# Altium schematic sheet RPi_DAQ_Hat_Connections.SchDoc(RPi_DAQ_Hat_Connections)
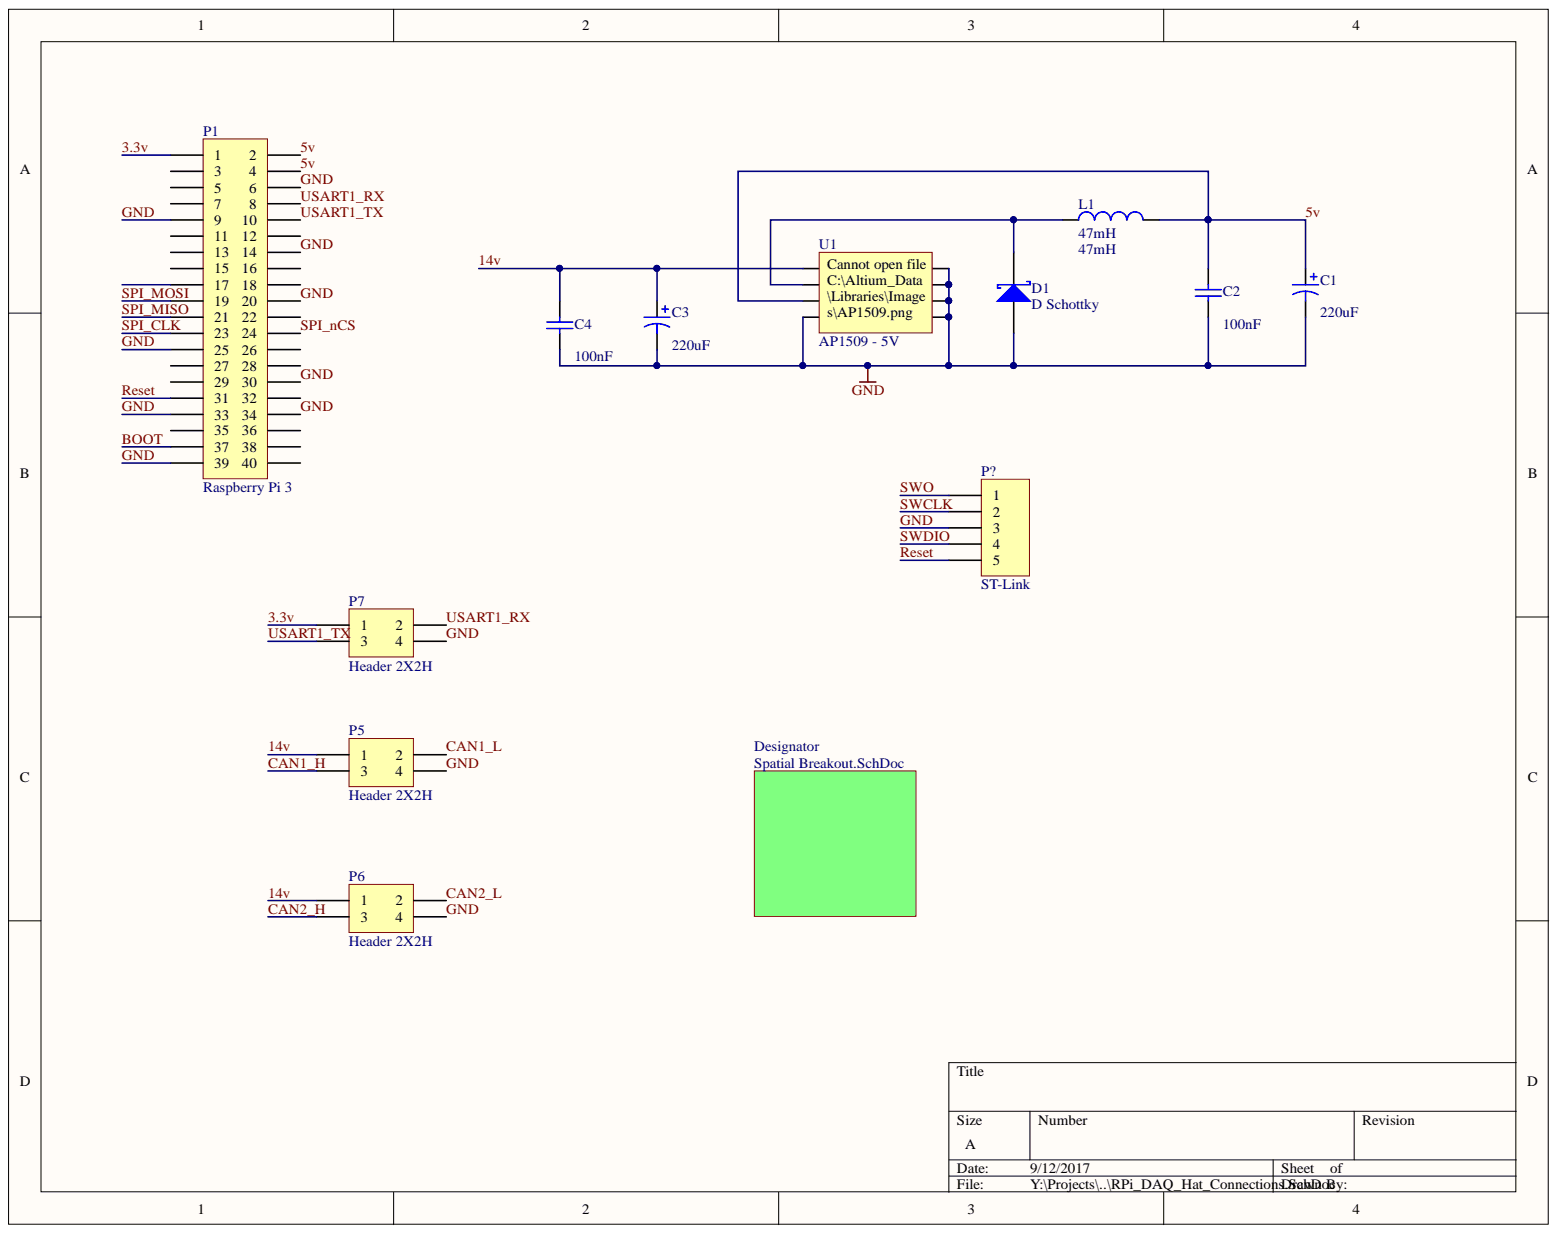
[diagram p0: RPi_DAQ_Hat_Connections.SchDoc]

## Parts
C1  220uF  [SMD Capacitor 220uF 16V]
C2  100nF  [6-0805_N]
C3  220uF  [SMD Capacitor 220uF 16V]
C4  100nF  [6-0805_L]
D1  D Schottky  [Diode]
L1  47mH  [Inductor]
P1  Raspberry Pi 3  [Rasberry Pi 3 Hat]
P5  Header 2X2H  [SMD 4Wire]
P6  Header 2X2H  [SMD 4Wire]
P7  Header 2X2H  [SMD 4Wire]
P?  ST-Link  [SMD 5Wire]
U1  AP1509 - 5V  [SOP8]

## Nets
3.3V: P1.1 P7.1
5V: C1.1 C2.2 L1.2 P1.2 P1.4 U1.3
14V: C3.1 C4.2 P5.1 P6.1 U1.1
BOOT: P1.37
CAN1_H: P5.3
CAN1_L: P5.2
CAN2_H: P6.3
CAN2_L: P6.2
GND: C1.2 C2.1 C3.2 C4.1 D1.1 P1.6 P1.9 P1.14 P1.20 P1.25 P1.30 P1.33 P1.34 P1.39 P5.4 P6.4 P7.4 P?.3 U1.4 U1.5 U1.6 U1.7 U1.8
RESET: P1.31 P?.5
SPI_CLK: P1.23
SPI_MISO: P1.21
SPI_MOSI: P1.19
SPI_NCS: P1.24
SWCLK: P?.2
SWDIO: P?.4
SWO: P?.1
USART1_RX: P1.8 P7.2
USART1_TX: P1.10 P7.3
NetD1_2: D1.2 L1.1 U1.2

Not connected: P1 3 5 7 11 12 13 15 16 17 18 22 26 27 28 29 32 35 36 38 40

## Library data of the parts
C1 C3 = Cap Pol1: Polarized Capacitor (Radial); Manufacturer: PANASONIC ELECTRONIC COMPONENTS; Manufacturer Part Number: EEE-FPA221XAP; Component Kind: Standard; ComponentLink1Description: http://www.farnell.com/datasheets/370232.pdf; ComponentLink1URL: http://www.farnell.com/datasheets/370232.pdf; ComponentLink2Description: http://www.farnell.com/cad/1703920.zip; ComponentLink2URL: http://www.farnell.com/cad/1703920.zip; LatestRevisionDate: 17-Jul-2002; LatestRevisionNote: Re-released for DXP Platform.; Library Name: Miscellaneous Devices.IntLib; PackageDescription: Polarized Capacitor (Radial); 2 Leads; PackageReference: RB7.6-15; Pricing 1: 1=0.965, 10=0.796, 25=0.654, 50=0.571, 100=0.498, 250=0.392 (USD); Published: 8-Jun-2000; RoHS: YES; Signal Integrity: Cap Pol; Simulation: CAP; Stock 1: 0; Supplier 1: Newark; Supplier Part Number 1: 94M6987; Value: 220uF; Publisher: Altium Limited
C2 C4 = Cap: Capacitor; Manufacturer: KEMET; Manufacturer Part Number: C0805C104K5RACTU; Component Kind: Standard; ComponentLink1Description: http://www.farnell.com/cad/1558681.zip; ComponentLink1URL: http://www.farnell.com/cad/1558681.zip; ComponentLink2Description: http://www.farnell.com/datasheets/1828800.pdf; ComponentLink2URL: http://www.farnell.com/datasheets/1828800.pdf; ComponentLink3Description: http://www.kemet.com/kemet/web/homepage/kechome.nsf/file/Commercial%20Ceramic%20Chips%20X7R%20Dielectric/$file/F3102_X7R.pdf; ComponentLink3URL: http://www.kemet.com/kemet/web/homepage/kechome.nsf/file/Commercial%20Ceramic%20Chips%20X7R%20Dielectric/$file/F3102_X7R.pdf; LatestRevisionDate: 17-Jul-2002; LatestRevisionNote: Re-released for DXP Platform.; Library Name: Miscellaneous Devices.IntLib; PackageDescription: Radial Cap, Thru-Hole; 2 Leads; 0.3 in Pin Spacing; PackageReference: RAD-0.3; Pricing 1: 4000=0.008, 8000=0.006, 12000=0.006, 28000=0.005 (USD); Published: 8-Jun-2000; RoHS: YES; Signal Integrity: Cap; Simulation: CAP; Stock 1: 584000; Supplier 1: Newark; Supplier Part Number 1: 65H7431; Value: 100nF; Publisher: Altium Limited
D1 = D Schottky: Schottky Diode; Code_JEDEC: DO-214-AA; Component Kind: Standard; LatestRevisionDate: 17-Jul-2002; LatestRevisionNote: Re-released for DXP Platform.; Library Name: Miscellaneous Devices.IntLib; PackageDescription: DO-214-AA/SMB; 2 C-Bend Leads; Body 5.3 x 3.6 mm, inc. leads (LxW); PackageReference: SMB; Published: 8-Jun-2000; Signal Integrity: D Schottky; Simulation: SKYDIODE; Publisher: Altium Limited
L1 = Inductor: Manufacturer Part Number: 1255AY-470M=P3; Brand: Murata - TOKO; Code_IEC: 1005; Core Material: Ferrite; Current Rating: 1.4A; DC Resistance (DCR): 252 mOhm Max; Frequency - Test: 100kHz; Inductance: 47 uH; Maximum DC Current: 1.4 A; Maximum DC Resistance: 252 mOhms; Product Status: New Product; Shielding: Shielded; Test Frequency: 100 kHz; Tolerance: 20 %; Type: Ferrite Inductor; Category: Fixed Inductors; Code_JEITA: 0402; Component Kind: Standard; ComponentLink1Description: http://www.mouser.com/ds/2/281/m_dg6045c-610991.pdf; ComponentLink1URL: http://www.mouser.com/ds/2/281/m_dg6045c-610991.pdf; ComponentLink2Description: http://www.toko.co.jp/products-murata/pdf/inductors/m_dg6045c.pdf; ComponentLink2URL: http://www.toko.co.jp/products-murata/pdf/inductors/m_dg6045c.pdf; Current - Saturation: -; Description: Inductor; Frequency - Self Resonant: -; Height: 4.5 mm; Height - Seated (Max): 0.177" (4.50mm); LatestRevisionDate: 29-May-2009; LatestRevisionNote: IPC-7351 Footprint Added.; Length: 6.3 mm; Library Name: Miscellaneous Devices.IntLib; Manufacturer: Murata - TOKO; Material - Core: Ferrite; Minimum Order Quantity: 1; Mounting Style: SMD/SMT; Mounting Type: Surface Mount; Operating Temperature: -; Package / Case: Nonstandard; PackageDescription: Chip Inductor; PackageReference: 0402-A; Packaging: Reel; Pricing 1: 1=0.752, 10=0.547, 100=0.387, 1500=0.286, 3000=0.261, 9000=0.253, 24000=0.245, 49500=0.235 (AUD); Pricing 2: 1=0.67, 10=0.598, 25=0.5608, 50=0.4862, 100=0.4488, 250=0.42636, 500=0.38898, 750=0.34409 (AUD); Product: Inductors; Published: 8-Jun-2000; Q @ Freq: -; Ratings: -; Reeling: True; RoHS: RoHS Compliant; RoHS - Mouser: Y; Series: DG6045C; Signal Integrity: Inductor; Simulation: INDUCTOR; Size / Dimension: 0.248" L x 0.248" W (6.30mm x 6.30mm); Stock 1: 1938; Stock 2: 3431; Supplier 1: Mouser; Supplier 2: Digi-Key; Supplier Part Number 2: 490-10811-1-ND; Termination Style: SMD/SMT; Value: 47mH; Width: 6.3 mm; Publisher: Altium Limited
P1 = Header 20X2H: Header, 20-Pin, Dual row, Right Angle; Component Kind: Standard; LatestRevisionDate: 17-Jul-2002; LatestRevisionNote: Re-released for DXP Platform.; Library Name: Miscellaneous Connectors.IntLib; Signal Integrity: Connector; Publisher: Altium Limited
P5-P7 = Header 2X2H: Header, 2-Pin, Dual row, Right Angle; Component Kind: Standard; LatestRevisionDate: 17-Jul-2002; LatestRevisionNote: Re-released for DXP Platform.; Library Name: Miscellaneous Connectors.IntLib; Signal Integrity: Connector; Publisher: Altium Limited
P? = Header 5: Header, 5-Pin; Component Kind: Standard; LatestRevisionDate: 17-Jul-2002; LatestRevisionNote: Re-released for DXP Platform.; Library Name: Miscellaneous Connectors.IntLib; Signal Integrity: Connector; Publisher: Altium Limited
U1 = AP1509: Component Kind: Standard; Library Name: ICs.SchLib
